# Altium schematic sheet FPGA Power.SchDoc("FPGA Power")
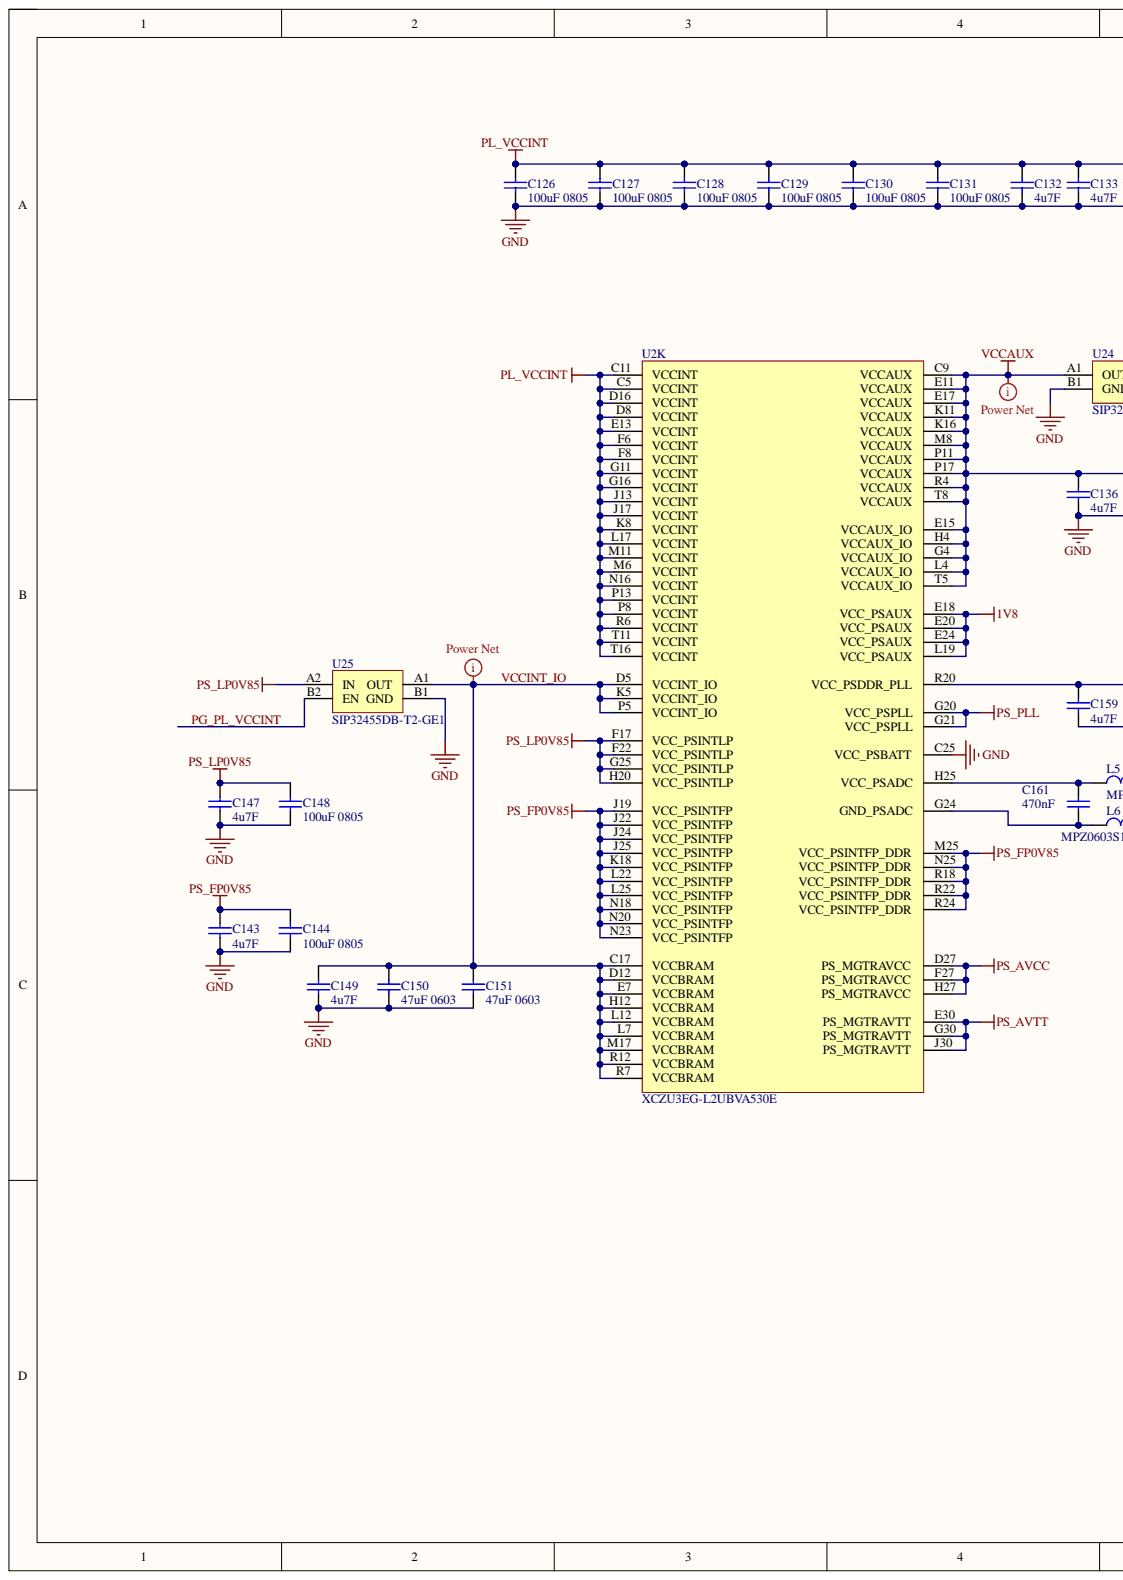
[diagram p0: FPGA Power.SchDoc, left]
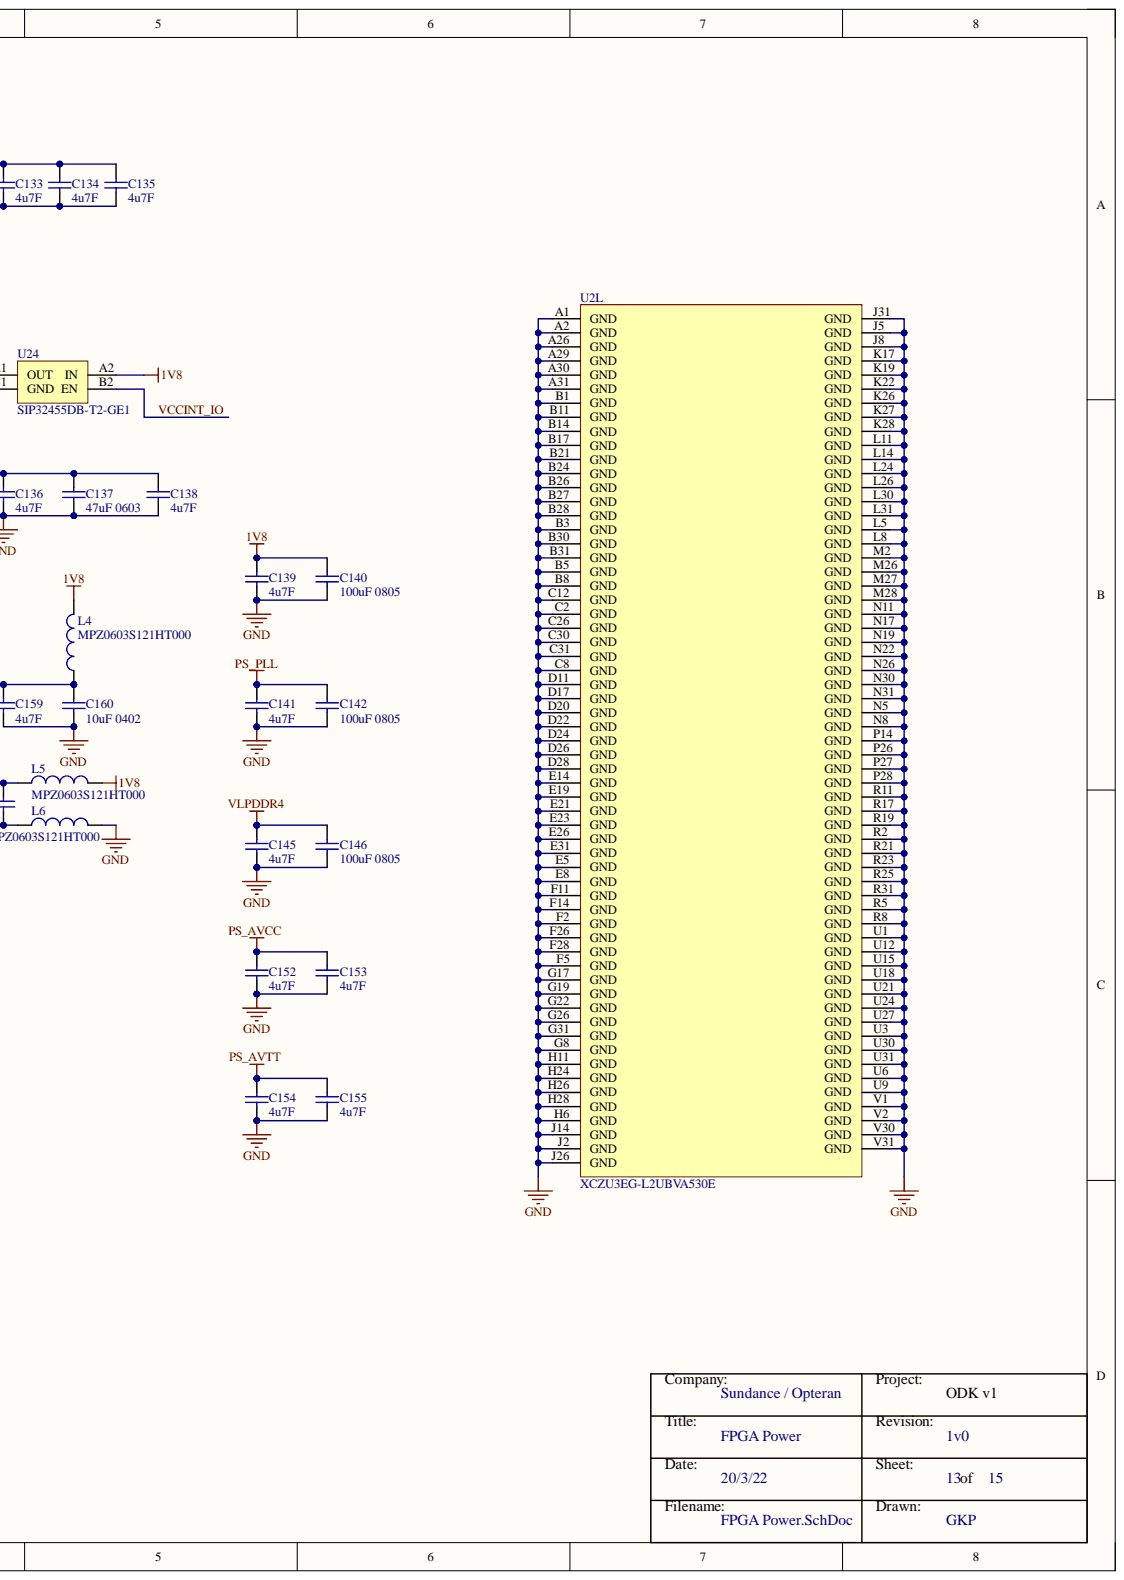
[diagram p1: FPGA Power.SchDoc, right]

## Parts
C126  100uF 0805  [CAP0805]
C127  100uF 0805  [CAP0805]
C128  100uF 0805  [CAP0805]
C129  100uF 0805  [CAP0805]
C130  100uF 0805  [CAP0805]
C131  100uF 0805  [CAP0805]
C132  4u7F  [0201panERJ]
C133  4u7F  [0201panERJ]
C134  4u7F  [0201panERJ]
C135  4u7F  [0201panERJ]
C136  4u7F  [0201panERJ]
C137  47uF 0603  Case-EIA: 0603  [CAP0603]
C138  4u7F  [0201panERJ]
C139  4u7F  [0201panERJ]
C140  100uF 0805  [CAP0805]
C141  4u7F  [0201panERJ]
C142  100uF 0805  [CAP0805]
C143  4u7F  [0201panERJ]
C144  100uF 0805  [CAP0805]
C145  4u7F  [0201panERJ]
C146  100uF 0805  [CAP0805]
C147  4u7F  [0201panERJ]
C148  100uF 0805  [CAP0805]
C149  4u7F  [0201panERJ]
C150  47uF 0603  [CAP0603]
C151  47uF 0603  Case-EIA: 0603  [CAP0603]
C152  4u7F  [0201panERJ]
C153  4u7F  [0201panERJ]
C154  4u7F  [0201panERJ]
C155  4u7F  [0201panERJ]
C159  4u7F  [0201panERJ]
C160  10uF 0402  Case-EIA: 0402  [CAP0402]
C161  470nF  [0201panERJ]
L4  MPZ0603S121HT000  [Inductor 0201]
L5  MPZ0603S121HT000  [Inductor 0201]
L6  MPZ0603S121HT000  [Inductor 0201]
U2  XCZU3EG-L2UBVA530E  [UBVA530]  (parts K,L)
U24  SIP32455DB-T2-GE1  [BGA4C40P2X2_76X76X54]
U25  SIP32455DB-T2-GE1  [BGA4C40P2X2_76X76X54]

## Nets
1V8: C139.2 C140.2 L4.2 L5.2 U2.E18(VCC_PSAUX) U2.E20(VCC_PSAUX) U2.E24(VCC_PSAUX) U2.L19(VCC_PSAUX) U24.A2(IN)
GND: C126.1 C127.1 C128.1 C129.1 C130.1 C131.1 C132.1 C133.1 C134.1 C135.1 C136.1 C137.1 C138.1 C139.1 C140.1 C141.1 C142.1 C143.1 C144.1 C145.1 C146.1 C147.1 C148.1 C149.1 C150.1 C151.1 C152.1 C153.1 C154.1 C155.1 C159.1 C160.1 L6.2 U2.A1(GND) U2.A2(GND) U2.A26(GND) U2.A29(GND) U2.A30(GND) U2.A31(GND) U2.B1(GND) U2.B3(GND) U2.B5(GND) U2.B8(GND) U2.B11(GND) U2.B14(GND) U2.B17(GND) U2.B21(GND) U2.B24(GND) U2.B26(GND) U2.B27(GND) U2.B28(GND) U2.B30(GND) U2.B31(GND) U2.C2(GND) U2.C8(GND) U2.C12(GND) U2.C25(VCC_PSBATT) U2.C26(GND) U2.C30(GND) U2.C31(GND) U2.D11(GND) U2.D17(GND) U2.D20(GND) U2.D22(GND) U2.D24(GND) U2.D26(GND) U2.D28(GND) U2.E5(GND) U2.E8(GND) U2.E14(GND) U2.E19(GND) U2.E21(GND) U2.E23(GND) U2.E26(GND) U2.E31(GND) U2.F2(GND) U2.F5(GND) U2.F11(GND) U2.F14(GND) U2.F26(GND) U2.F28(GND) U2.G8(GND) U2.G17(GND) U2.G19(GND) U2.G22(GND) U2.G26(GND) U2.G31(GND) U2.H6(GND) U2.H11(GND) U2.H24(GND) U2.H26(GND) U2.H28(GND) U2.J2(GND) U2.J5(GND) U2.J8(GND) U2.J14(GND) U2.J26(GND) U2.J31(GND) U2.K17(GND) U2.K19(GND) U2.K22(GND) U2.K26(GND) U2.K27(GND) U2.K28(GND) U2.L5(GND) U2.L8(GND) U2.L11(GND) U2.L14(GND) U2.L24(GND) U2.L26(GND) U2.L30(GND) U2.L31(GND) U2.M2(GND) U2.M26(GND) U2.M27(GND) U2.M28(GND) U2.N5(GND) U2.N8(GND) U2.N11(GND) U2.N17(GND) U2.N19(GND) U2.N22(GND) U2.N26(GND) U2.N30(GND) U2.N31(GND) U2.P14(GND) U2.P26(GND) U2.P27(GND) U2.P28(GND) U2.R2(GND) U2.R5(GND) U2.R8(GND) U2.R11(GND) U2.R17(GND) U2.R19(GND) U2.R21(GND) U2.R23(GND) U2.R25(GND) U2.R31(GND) U2.U1(GND) U2.U3(GND) U2.U6(GND) U2.U9(GND) U2.U12(GND) U2.U15(GND) U2.U18(GND) U2.U21(GND) U2.U24(GND) U2.U27(GND) U2.U30(GND) U2.U31(GND) U2.V1(GND) U2.V2(GND) U2.V30(GND) U2.V31(GND) U24.B1(GND) U25.B1(GND)
PL_VCCINT: C126.2 C127.2 C128.2 C129.2 C130.2 C131.2 C132.2 C133.2 C134.2 C135.2 U2.C5(VCCINT) U2.C11(VCCINT) U2.D8(VCCINT) U2.D16(VCCINT) U2.E13(VCCINT) U2.F6(VCCINT) U2.F8(VCCINT) U2.G11(VCCINT) U2.G16(VCCINT) U2.J13(VCCINT) U2.J17(VCCINT) U2.K8(VCCINT) U2.L17(VCCINT) U2.M6(VCCINT) U2.M11(VCCINT) U2.N16(VCCINT) U2.P8(VCCINT) U2.P13(VCCINT) U2.R6(VCCINT) U2.T11(VCCINT) U2.T16(VCCINT)
PS_AVCC: C152.2 C153.2 U2.D27(PS_MGTRAVCC) U2.F27(PS_MGTRAVCC) U2.H27(PS_MGTRAVCC)
PS_AVTT: C154.2 C155.2 U2.E30(PS_MGTRAVTT) U2.G30(PS_MGTRAVTT) U2.J30(PS_MGTRAVTT)
PS_FP0V85: C143.2 C144.2 U2.J19(VCC_PSINTFP) U2.J22(VCC_PSINTFP) U2.J24(VCC_PSINTFP) U2.J25(VCC_PSINTFP) U2.K18(VCC_PSINTFP) U2.L22(VCC_PSINTFP) U2.L25(VCC_PSINTFP) U2.M25(VCC_PSINTFP_DDR) U2.N18(VCC_PSINTFP) U2.N20(VCC_PSINTFP) U2.N23(VCC_PSINTFP) U2.N25(VCC_PSINTFP_DDR) U2.R18(VCC_PSINTFP_DDR) U2.R22(VCC_PSINTFP_DDR) U2.R24(VCC_PSINTFP_DDR)
PS_LP0V85: C147.2 C148.2 U2.F17(VCC_PSINTLP) U2.F22(VCC_PSINTLP) U2.G25(VCC_PSINTLP) U2.H20(VCC_PSINTLP) U25.A2(IN)
PS_PLL: C141.2 C142.2 U2.G20(VCC_PSPLL) U2.G21(VCC_PSPLL)
VCCAUX: C136.2 C137.2 C138.2 U2.C9(VCCAUX) U2.E11(VCCAUX) U2.E15(VCCAUX_IO) U2.E17(VCCAUX) U2.G4(VCCAUX_IO) U2.H4(VCCAUX_IO) U2.K11(VCCAUX) U2.K16(VCCAUX) U2.L4(VCCAUX_IO) U2.M8(VCCAUX) U2.P11(VCCAUX) U2.P17(VCCAUX) U2.R4(VCCAUX) U2.T5(VCCAUX_IO) U2.T8(VCCAUX) U24.A1(OUT)
VLPDDR4: C145.2 C146.2
PG_PL_VCCINT: U25.B2(EN)
VCCINT_IO: C149.2 C150.2 C151.2 U2.C17(VCCBRAM) U2.D5(VCCINT_IO) U2.D12(VCCBRAM) U2.E7(VCCBRAM) U2.H12(VCCBRAM) U2.K5(VCCINT_IO) U2.L7(VCCBRAM) U2.L12(VCCBRAM) U2.M17(VCCBRAM) U2.P5(VCCINT_IO) U2.R7(VCCBRAM) U2.R12(VCCBRAM) U24.B2(EN) U25.A1(OUT)
NetC159_2: C159.2 C160.2 L4.1 U2.R20(VCC_PSDDR_PLL)
NetC161_1: C161.1 L6.1 U2.G24(GND_PSADC)
NetC161_2: C161.2 L5.1 U2.H25(VCC_PSADC)

## Library data of the parts
C126-C131 C140 C142 C144 C146 C148 = Cap0805: 100uF 4V 0805 X5R; Case-EIA: 0603; Component Kind: Standard; Library Name: Passive LCR.SchLib; Max Thickness: 0.6 mm; Technology: SMT
C132-C136 C138 C139 C141 C143 C145 C147 C149 C152-C155 C159 = Cap0201: 4u7F 0201 6V3 X5R; Case-EIA: 0402; Component Kind: Standard; Library Name: Schematic Components.SchLib; Max Thickness: 0.6 mm; Technology: SMT
C137 C151 = Cap0603: 47uF 6V3 0603 X5R; Component Kind: Standard; Library Name: Schematic Components.SchLib; Max Thickness: 0.6 mm; Technology: SMT
C150 = Cap0603: 47uF 6V3 0603 X5R; Case-EIA: 0603; Component Kind: Standard; Library Name: Schematic Components.SchLib; Max Thickness: 0.6 mm; Technology: SMT
C160 = Cap0402: 10uF 0402 6V3 X5R; Component Kind: Standard; Library Name: Passive LCR.SchLib; Max Thickness: 0.6 mm; Technology: SMT
C161 = Cap0201: 470nF 0201 6V3 X5R; Case-EIA: 0402; Component Kind: Standard; Library Name: Schematic Components.SchLib; Max Thickness: 0.6 mm; Technology: SMT
L4-L6 = Inductor0201: 120 Ohms @ 100 MHz 1 Power Line Ferrite Bead 0201 (0603 Metric) 1A 130mOhm; Manufacturer 1: TDK Corporation; Component Kind: Standard; Library Name: Passive LCR.SchLib; Manufacturer Part Number 1: MPZ0603S121HT000; Supplier 1: Digikey; Supplier Part Number 1: 445-180338-2-ND
U2 = XCZU3EG-L2UBVA530E: SoC 32-bit/64-bit ARM Cortex-A53 RISC 1.5GHz Quad Core 530-Pin BGA; Manufacturer 1: Xilinx; Component Kind: Standard; Library Name: Xilinx1.SchLib; Manufacturer Part Number 1: XCZU3EG-L2UBVA530E; Supplier 1: Avnet; Supplier Part Number 1: XCZU3EG-L2UBVA530E
U24 U25 = SiP32455: Power Switch/Driver 1:1 N-Channel 1.2A 4-WCSP (0.76x0.76). 0.8-2.5V; Manufacturer 1: Vishay Siliconix; Component Kind: Standard; Library Name: Schematic Components.SchLib; Manufacturer Part Number 1: SIP32455DB-T2-GE1; Supplier 1: Digi-Key; Supplier Part Number 1: SIP32455DB-T2-GE1TR-ND
